# Altium schematic sheet Stepper_Outputs.SchDoc
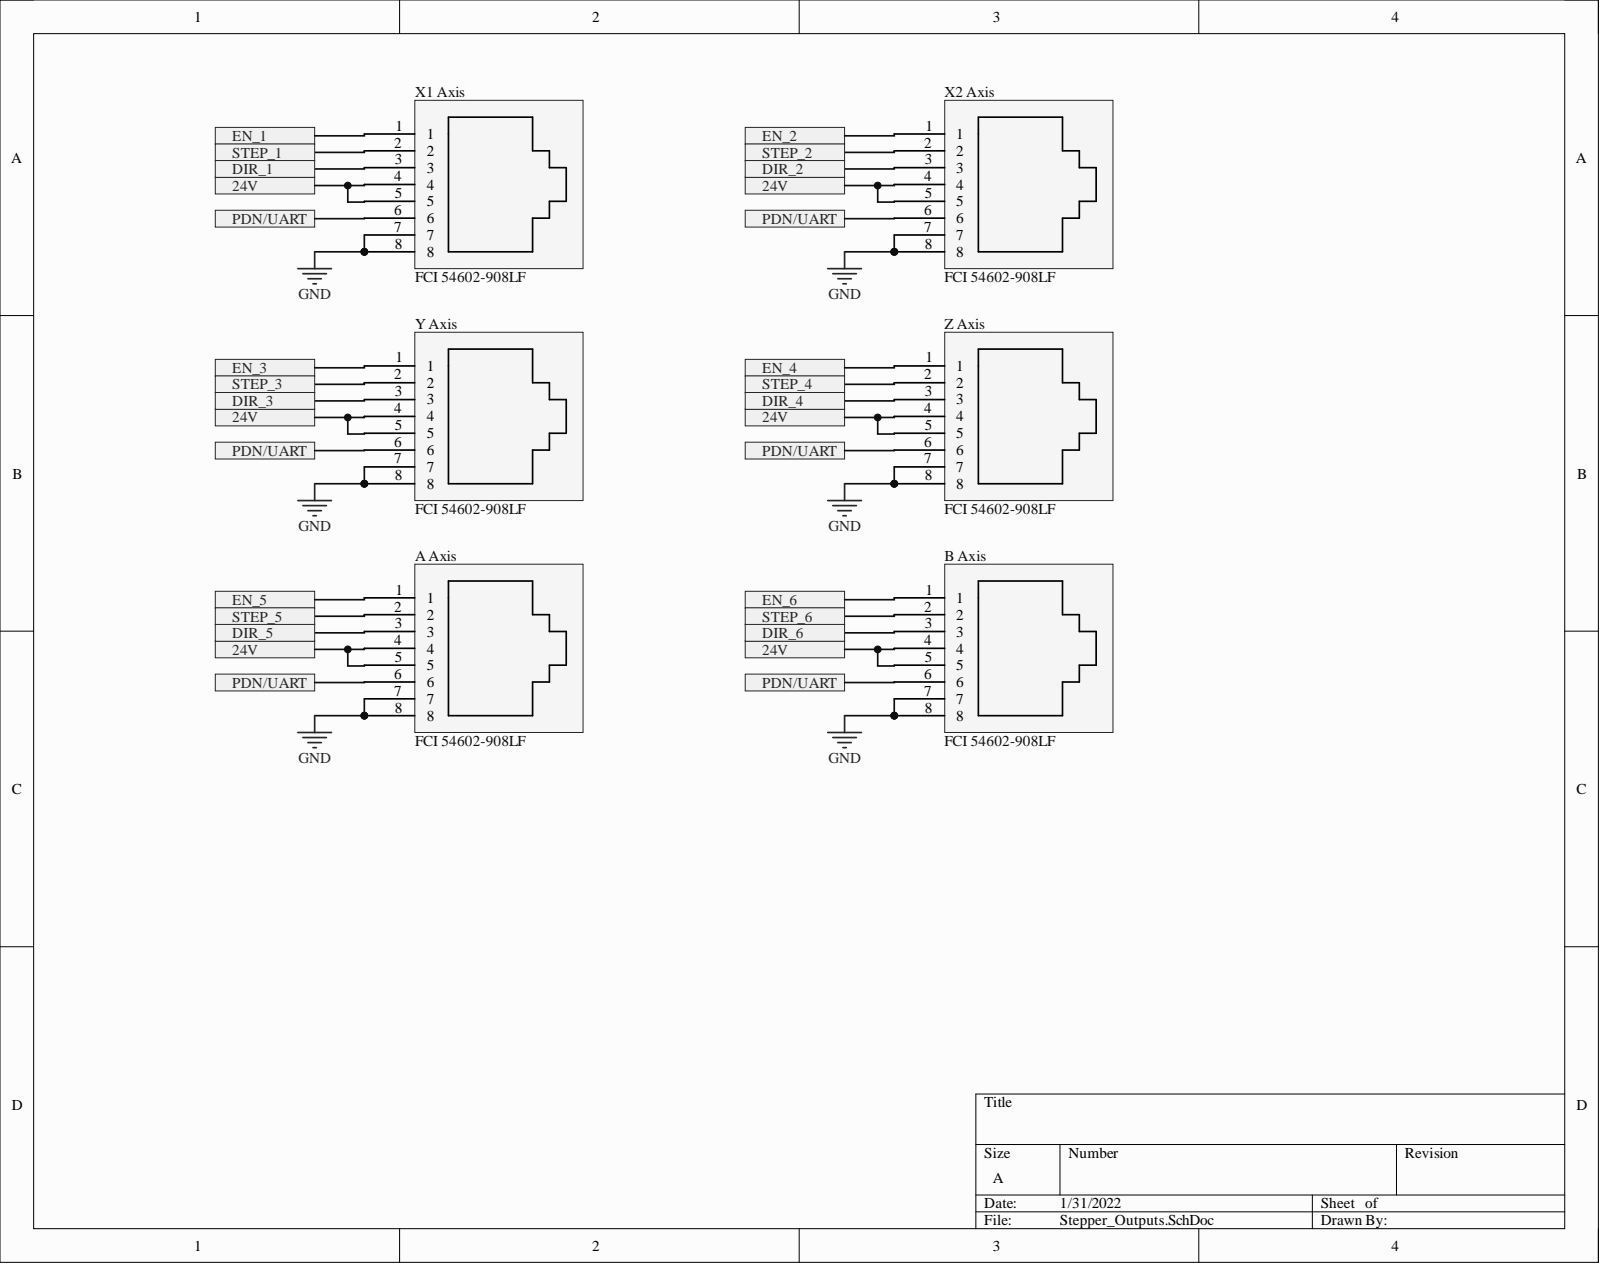
[diagram p0: Stepper_Outputs.SchDoc]

## Parts
A_Axis  FCI 54602-908LF  Manufacturer Part Number: 54602-908LF  [PCB-kyusfhn5h9rxu8er00w0-1]
B_Axis  FCI 54602-908LF  Manufacturer Part Number: 54602-908LF  [PCB-kyusfhn5h9rxu8er00w0-1]
X1_Axis  FCI 54602-908LF  Manufacturer Part Number: 54602-908LF  [PCB-kyusfhn5h9rxu8er00w0-1]
X2_Axis  FCI 54602-908LF  Manufacturer Part Number: 54602-908LF  [PCB-kyusfhn5h9rxu8er00w0-1]
Y_Axis  FCI 54602-908LF  Manufacturer Part Number: 54602-908LF  [PCB-kyusfhn5h9rxu8er00w0-1]
Z_Axis  FCI 54602-908LF  Manufacturer Part Number: 54602-908LF  [PCB-kyusfhn5h9rxu8er00w0-1]

## Ports
24V, DIR_1, DIR_2, DIR_3, DIR_4, DIR_5, DIR_6, EN_1, EN_2, EN_3, EN_4, EN_5, EN_6, PDN/UART, STEP_1, STEP_2, STEP_3, STEP_4, STEP_5, STEP_6

## Nets
GND: A_Axis.7 A_Axis.8 B_Axis.7 B_Axis.8 X1_Axis.7 X1_Axis.8 X2_Axis.7 X2_Axis.8 Y_Axis.7 Y_Axis.8 Z_Axis.7 Z_Axis.8
24V: A_Axis.4 A_Axis.5 B_Axis.4 B_Axis.5 X1_Axis.4 X1_Axis.5 X2_Axis.4 X2_Axis.5 Y_Axis.4 Y_Axis.5 Z_Axis.4 Z_Axis.5 ; port 24V
DIR_1: X1_Axis.3 ; port DIR_1
DIR_2: X2_Axis.3 ; port DIR_2
DIR_3: Y_Axis.3 ; port DIR_3
DIR_4: Z_Axis.3 ; port DIR_4
DIR_5: A_Axis.3 ; port DIR_5
DIR_6: B_Axis.3 ; port DIR_6
EN_1: X1_Axis.1 ; port EN_1
EN_2: X2_Axis.1 ; port EN_2
EN_3: Y_Axis.1 ; port EN_3
EN_4: Z_Axis.1 ; port EN_4
EN_5: A_Axis.1 ; port EN_5
EN_6: B_Axis.1 ; port EN_6
PDN/UART: A_Axis.6 B_Axis.6 X1_Axis.6 X2_Axis.6 Y_Axis.6 Z_Axis.6 ; port PDN/UART
STEP_1: X1_Axis.2 ; port STEP_1
STEP_2: X2_Axis.2 ; port STEP_2
STEP_3: Y_Axis.2 ; port STEP_3
STEP_4: Z_Axis.2 ; port STEP_4
STEP_5: A_Axis.2 ; port STEP_5
STEP_6: B_Axis.2 ; port STEP_6

## Library data of the parts
A_Axis B_Axis X1_Axis X2_Axis Y_Axis Z_Axis = CMP-1a15d1442034c5d2-8: Conn Modular Jack F 8 POS 2.54mm Solder RA Thru-Hole 8 Terminal 1 Port Box Cat 3; Gender: Male; Lead-Free Status: Lead Free; Number of Contacts: 8; Color: Black; Component Kind: Standard; Contact Material: Phosphor Bronze; Contact Plating: Gold; Library Name: CommunityVault; Mounting Style: Through Hole, PCB; Octopart URL: http://octopart.com/54602-908lf-fci-39526215; OctopartID: 1a15d1442034c5d2; REACH SVHC Compliance: No SVHC; RoHS: Compliant; Size-Height: 12.7 mm; Size-Length: 15.3 mm; Size-Width: 17.7 mm
